# Altium schematic sheet MCU.SchDoc(MCU)
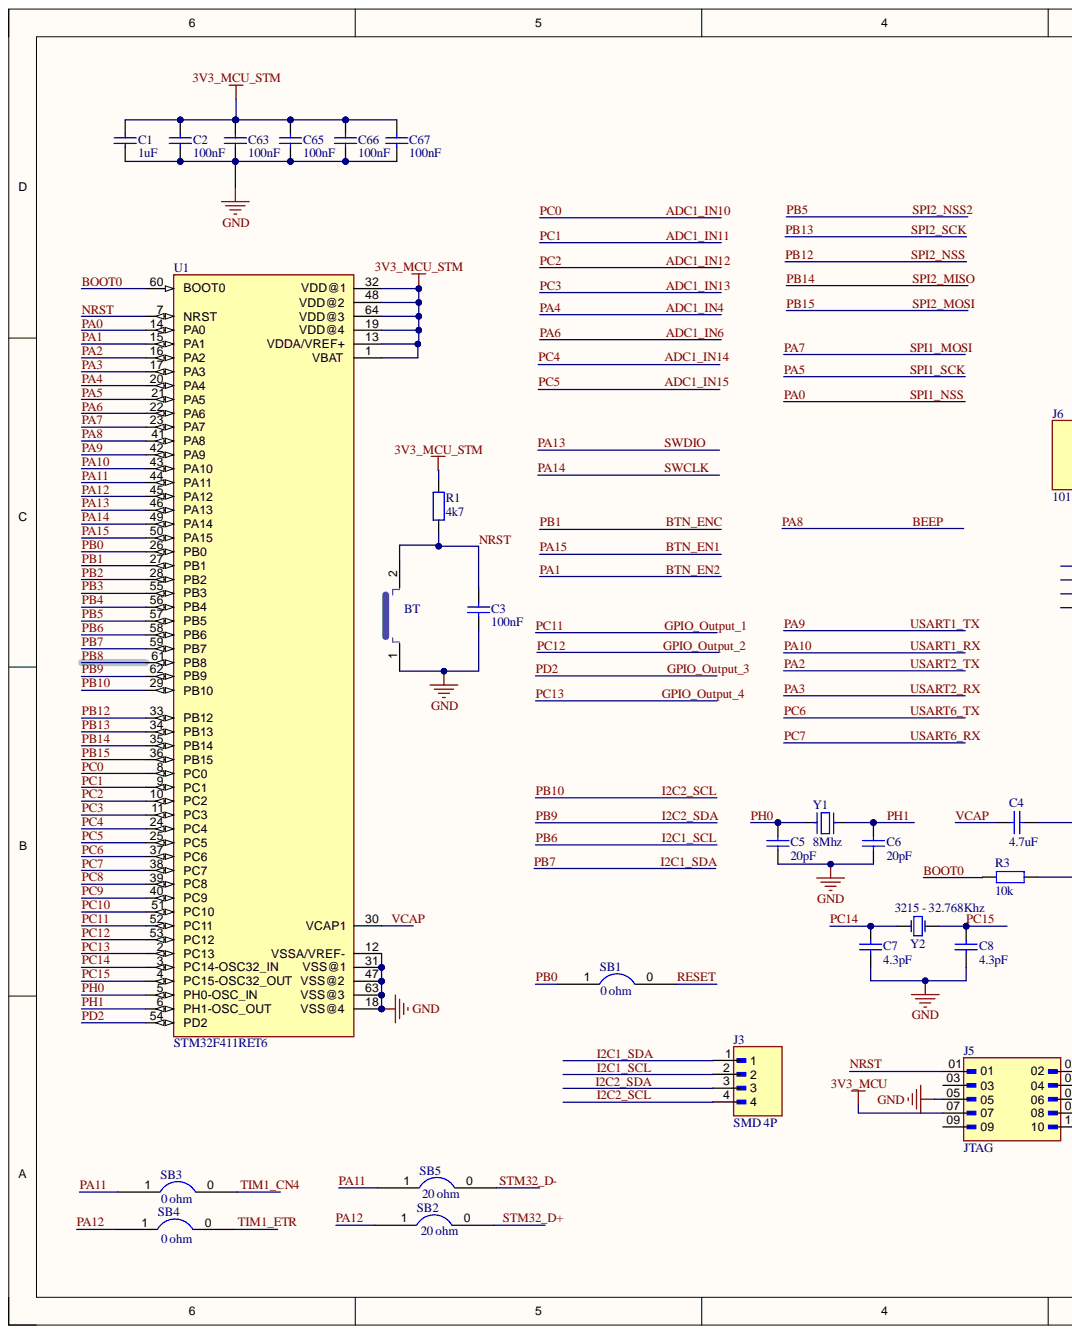
[diagram p0: MCU.SchDoc, left]
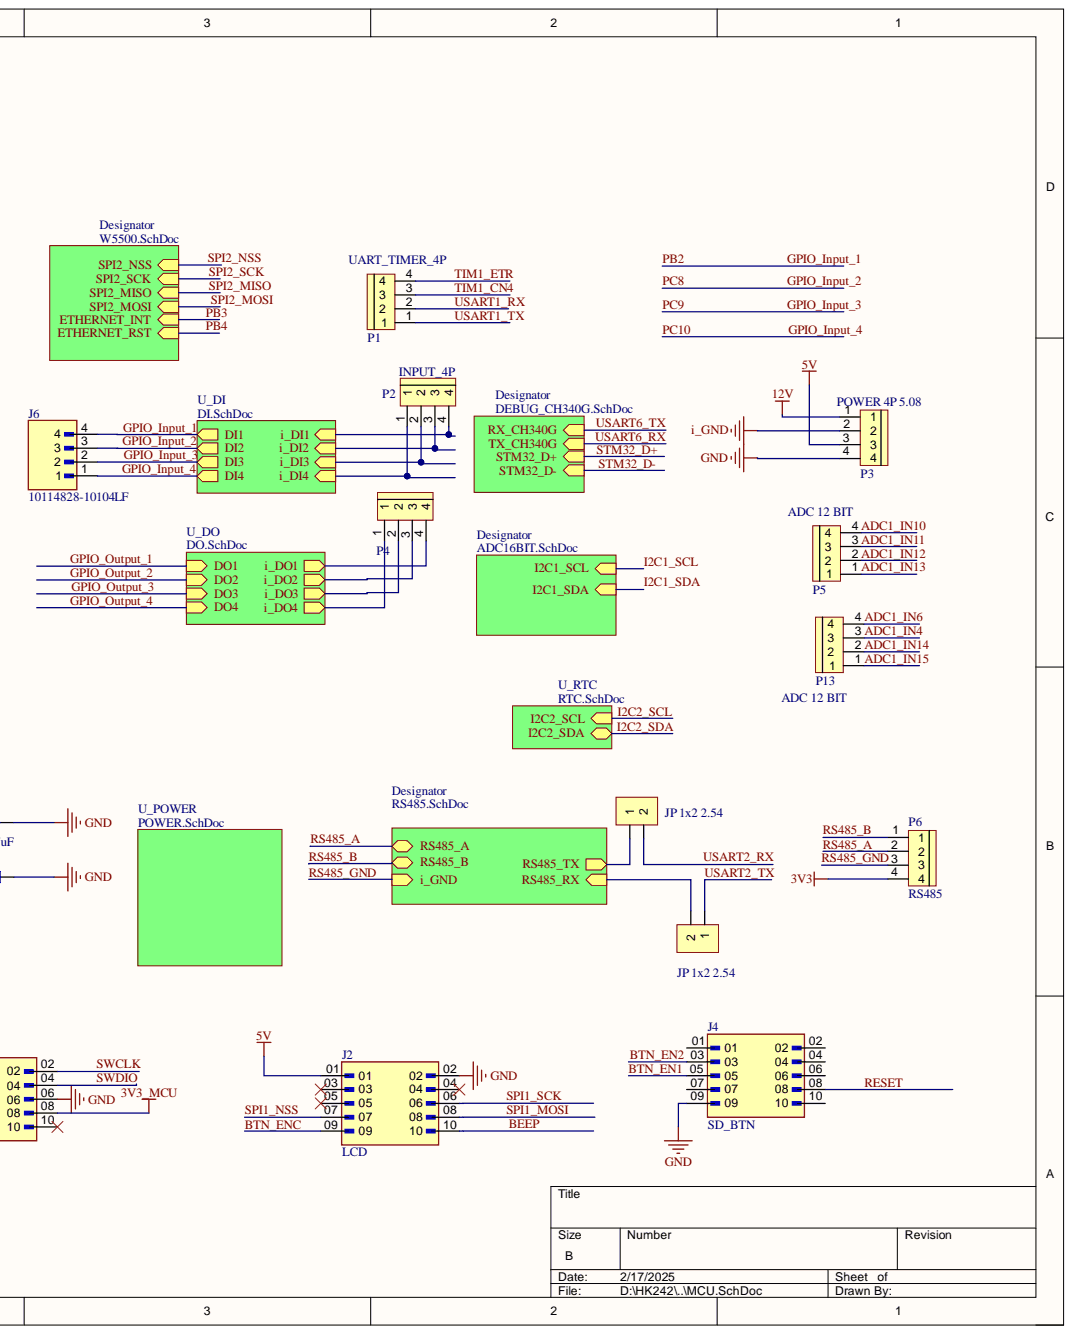
[diagram p1: MCU.SchDoc, right]

## Parts
BT1  BT  [SW-SMD]
C1  1uF  [0603_Cap]
C2  100nF  [0603_Cap]
C3  100nF  [0603_Cap]
C4  4.7uF  [0603_Cap]
C5  20pF  [0603_Cap]
C6  20pF  [0603_Cap]
C7  4.3pF  [0603_Cap]
C8  4.3pF  [0603_Cap]
C63  100nF  [0603_Cap]
C65  100nF  [0603_Cap]
C66  100nF  [0603_Cap]
C67  100nF  [0603_Cap]
J2  LCD  [AMPHENOL_52601-S10-8TLF]
J3  SMD 4P  [AMPHENOL_10114828-10104LF]
J4  SD_BTN  [AMPHENOL_52601-S10-8TLF]
J5  JTAG  [AMPHENOL_52601-S10-8TLF]
J6  10114828-10104LF  [AMPHENOL_10114828-10104LF]
JP4  JP 1x2 2.54  [JP 1x2 DT]
JP5  JP 1x2 2.54  [JP 1x2 DT]
P1  UART_TIMER_4P  [CON 4 4EDG5.08]
P2  INPUT_4P  [CON 4 4EDG5.08]
P3  POWER 4P 5.08  [CON 4 4EDG5.08]
P4  CON 4 EDG5.08  [CON 4 4EDG5.08]  (value not drawn)
P5  ADC 12 BIT  [CON 4 4EDG5.08]
P6  RS485  [CON 4 4EDG5.08]
P13  ADC 12 BIT  [CON 4 4EDG5.08]
R1  4k7  [0603_Res]
R3  10k  [0603_Res]
SB1  0 ohm  [Solder Bridge]
SB2  20 ohm  [Solder Bridge]
SB3  0 ohm  [Solder Bridge]
SB4  0 ohm  [Solder Bridge]
SB5  20 ohm  [Solder Bridge]
U1  STM32F411RET6  [QFP50P1200X1200X160-64N]
Y1  8Mhz  [Thach Anh 2 chan cam]
Y2  3215 - 32.768Khz  [THACH ANH DAN 3215]

## Nets
3V3: P6.4
3V3_MCU: J5.07 J5.08
3V3_MCU_STM: C1.1 C2.1 C63.1 C65.1 C66.1 C67.1 R1.2 U1.1(VBAT) U1.13(VDDA/VREF+) U1.19(VDD@4) U1.32(VDD@1) U1.48(VDD@2) U1.64(VDD@3)
5V: J2.01 P3.3
12V: P3.1
GND: BT1.1 C1.2 C2.2 C3.1 C4.1 C5.1 C6.1 C7.1 C8.1 C63.2 C65.2 C66.2 C67.2 J2.02 J4.09 J5.05 J5.06 P3.4 R3.1 U1.12(VSSA/VREF-) U1.18(VSS@4) U1.31(VSS@1) U1.47(VSS@2) U1.63(VSS@3)
i_GND: P3.2
ADC1_IN4: P13.3 U1.20(PA4)
ADC1_IN6: P13.4 U1.22(PA6)
ADC1_IN10: P5.4 U1.8(PC0)
ADC1_IN11: P5.3 U1.9(PC1)
ADC1_IN12: P5.2 U1.10(PC2)
ADC1_IN13: P5.1 U1.11(PC3)
ADC1_IN14: P13.2 U1.24(PC4)
ADC1_IN15: P13.1 U1.25(PC5)
BEEP: J2.10 U1.41(PA8)
BOOT0: R3.2 U1.60(BOOT0)
BTN_EN1: J4.05 U1.50(PA15)
BTN_EN2: J4.03 U1.15(PA1)
BTN_ENC: J2.09 U1.27(PB1)
GPIO_Input_1: J6.4 U1.28(PB2)
GPIO_Input_2: J6.3 U1.39(PC8)
GPIO_Input_3: J6.2 U1.40(PC9)
GPIO_Input_4: J6.1 U1.51(PC10)
GPIO_Output_1: U1.52(PC11)
GPIO_Output_2: U1.53(PC12)
GPIO_Output_3: U1.54(PD2)
GPIO_Output_4: U1.2(PC13)
I2C1_SCL: J3.2 U1.58(PB6)
I2C1_SDA: J3.1 U1.59(PB7)
I2C2_SCL: J3.4 U1.29(PB10)
I2C2_SDA: J3.3 U1.62(PB9)
NRST: BT1.2 C3.2 J5.01 R1.1 U1.7(NRST)
PA0: J2.07 U1.14(PA0)
PA2: JP5.1 U1.16(PA2)
PA3: JP4.2 U1.17(PA3)
PA5: J2.06 U1.21(PA5)
PA7: J2.08 U1.23(PA7)
PA9: P1.1 U1.42(PA9)
PA10: P1.2 U1.43(PA10)
PA11: SB3.1 SB5.1 U1.44(PA11)
PA12: SB2.1 SB4.1 U1.45(PA12)
PA13: J5.04 U1.46(PA13)
PA14: J5.02 U1.49(PA14)
PB0: SB1.1 U1.26(PB0)
PB3: U1.55(PB3)
PB4: U1.56(PB4)
PB5: U1.57(PB5)
PB8: U1.61(PB8)
PB12: U1.33(PB12)
PB13: U1.34(PB13)
PB14: U1.35(PB14)
PB15: U1.36(PB15)
PC6: U1.37(PC6)
PC7: U1.38(PC7)
PC14: C7.2 U1.3(PC14-OSC32_IN) Y2.1
PC15: C8.2 U1.4(PC15-OSC32_OUT) Y2.2
PH0: C5.2 U1.5(PH0-OSC_IN) Y1.1
PH1: C6.2 U1.6(PH1-OSC_OUT) Y1.2
RESET: J4.08 SB1.0
RS485_A: P6.2
RS485_B: P6.1
RS485_GND: P6.3
STM32_D+: SB2.0
STM32_D-: SB5.0
TIM1_CN4: P1.3 SB3.0
TIM1_ETR: P1.4 SB4.0
VCAP: C4.2 U1.30(VCAP1)
NetJ2_03: J2.03
NetJ2_04: J2.04
NetJ2_05: J2.05
NetJ4_01: J4.01
NetJ4_02: J4.02
NetJ4_04: J4.04
NetJ4_06: J4.06
NetJ4_07: J4.07
NetJ4_10: J4.10
NetJ5_03: J5.03
NetJ5_09: J5.09
NetJ5_10: J5.10
NetJP4_1: JP4.1
NetJP5_2: JP5.2
NetP2_1: P2.1
NetP2_2: P2.2
NetP2_3: P2.3
NetP2_4: P2.4
NetP4_1: P4.1
NetP4_2: P4.2
NetP4_3: P4.3
NetP4_4: P4.4

## Library data of the parts
BT1 = BUTTON: Component Kind: Standard; Library Name: My library altium.IntLib
C1-C8 C63 C65-C67 = Ceramic Capacitor: Chung_Loai: Dán; Don_Vi: Con; Ma_Hang: MH004551; So_Chan: 2; Ten_Linh_Kien: Tụ 0805 104-100nF 10% 50V; Component Kind: Standard; Library Name: CMN.IntLib
J2 J4 J5 = 52601-S10-8TLF: Quickie IDC Cable-to-Board Connector System, Shrouded Vertical Header, Surface Mount, Low Profile, Double Row, 10 Positions, 2.54mm (0.100in) Pitch.; MF: Amphenol; Check_prices: https://www.snapeda.com/parts/52601-S10-8TLF/Amphenol/view-part/?ref=eda; Component Kind: Standard; Library Name: 52601-S10-8TLF.IntLib; MP: 52601-S10-8TLF; Package: None; SnapEDA_Link: https://www.snapeda.com/parts/52601-S10-8TLF/Amphenol/view-part/?ref=snap
J3 J6 = 10114828-10104LF: 1.25mm Wire to Board Wafer,Vertical, Surface Mount, 4 Positions; MF: AMPHENOL ICC / FCI; Check_prices: https://www.snapeda.com/parts/10114828-10104LF/Amphenol/view-part/?ref=eda; Component Kind: Standard; Library Name: 10114828-10104LF.IntLib; MP: 10114828-10104LF; Package: None; SnapEDA_Link: https://www.snapeda.com/parts/10114828-10104LF/Amphenol/view-part/?ref=snap
JP4 JP5 = JP 1x2 2.54 Duc Thang: Cắm; Don_Vi: Con; Ma_Hang: MH000903; Ten_Linh_Kien: Jump Đơn Đực 2.54MM 1x40P Thẳng 11MM; Chung_Loai: Cắm; Component Kind: Standard; Library Name: Altium_Lib_by_KhueNguyenCreator.IntLib
P1-P3 P5 P6 P13 = CON 4 EDG5.08: Cắm; Don_Vi: Con; Ma_Hang: MH000979; Ten_Linh_Kien: CON 4 2EDG5.08-4P 5.08MM ( Xanh Cong); Chung_Loai: Cắm; Component Kind: Standard; Library Name: Altium_Lib_by_KhueNguyenCreator.IntLib
P4 = CON 4 EDG5.08: Cắm; Ma_Hang: MH000979; Ten_Linh_Kien: CON 4 2EDG5.08-4P 5.08MM ( Xanh Cong); Chung_Loai: Cắm; Component Kind: Standard; Don_Vi: Con; Library Name: Altium_Lib_by_KhueNguyenCreator.IntLib
R1 R3 = Resistor: Chung_Loai: Dán; Don_Vi: Con; Ma_Hang: MH005468; So_Chan: 2; Ten_Linh_Kien: Trở 0805 1% 1k; Component Kind: Standard; Library Name: CMN.IntLib
SB1-SB5 = Solder Bridge: Component Kind: Standard; Library Name: Schlib1.SchLib
U1 = STM32F411RET6: ARMÂ® CortexÂ®-M4 STM32F4 Mikrodenetleyici IC 32-Bit Tek Ã‡ekirdek 100MHz 512KB (512K x 8) FLASH 64-LQFP (10x10); MF: STMicroelectronics; Check_prices: https://www.snapeda.com/parts/STM32F411RET6/STMicroelectronics/view-part/?ref=eda; Component Kind: Standard; Library Name: STM32F411RET6.IntLib; MP: STM32F411RET6; Package: LQFP-64 STMicroelectronics; SnapEDA_Link: https://www.snapeda.com/parts/STM32F411RET6/STMicroelectronics/view-part/?ref=snap
Y1 = Thach Anh 2 chan Cam: Crystal For all Crystal 2pin.; Value: 4Mhz; Component Kind: Standard; Library Name: Altium_Lib_by_KhueNguyenCreator.IntLib
Y2 = Thach Anh SMD 3225: C700805; Chung_Loai: Dán; Don_Vi: Con; Ma_Hang: MH001979; Ten_Linh_Kien: Thạch Anh 16Mhz SMD5032; Component Kind: Standard; Library Name: Altium_Lib_by_KhueNguyenCreator.IntLib
